# Altium schematic sheet sd card.SchDoc("sd card")
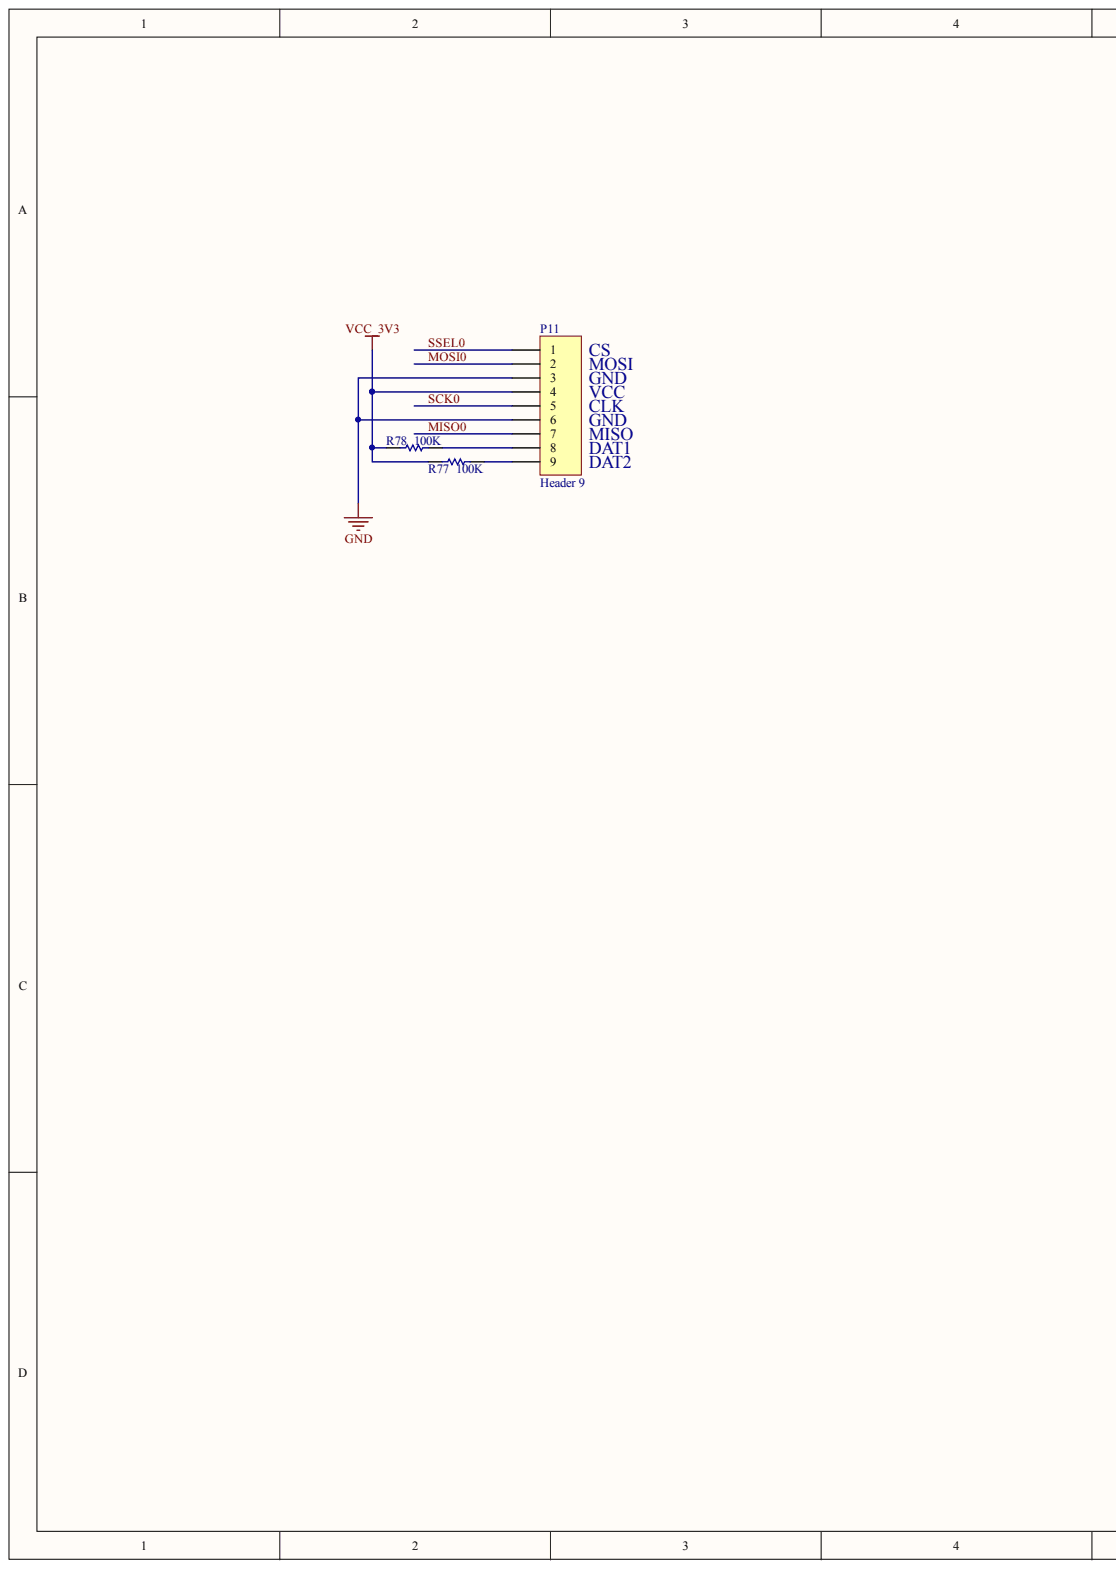
[diagram p0: sd card.SchDoc, left]
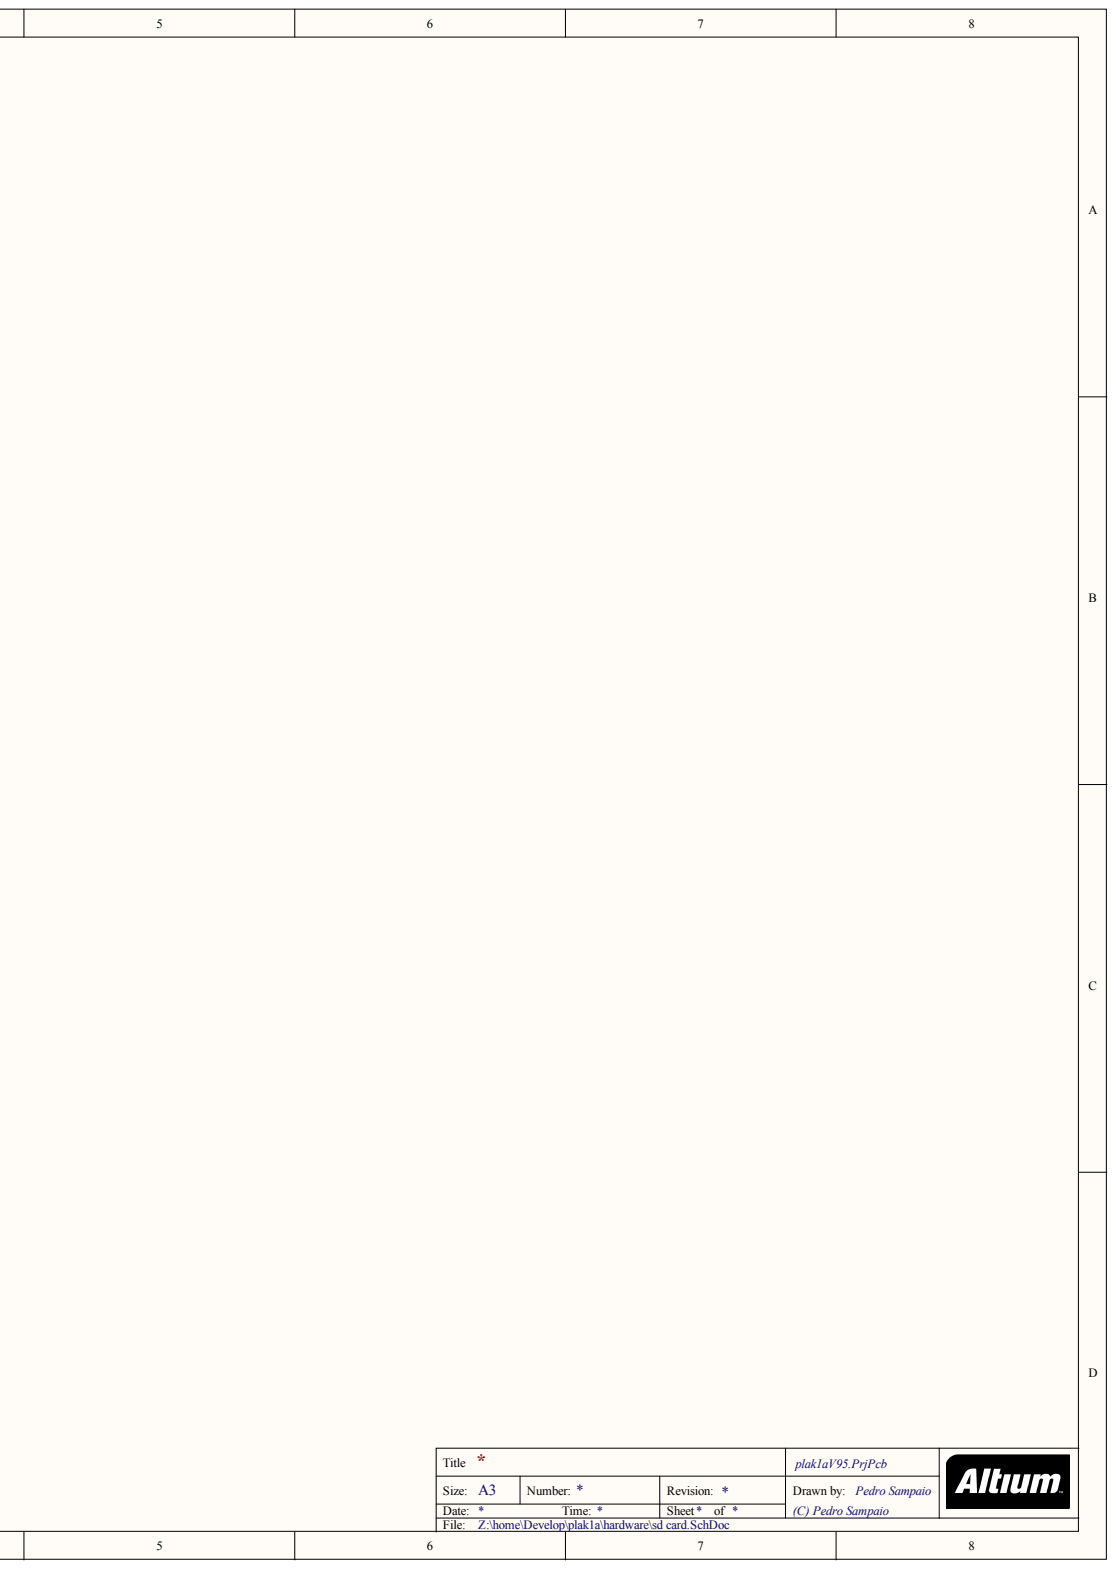
[diagram p1: sd card.SchDoc, right]

## Parts
P11  Header 9  [MC/SM2.5-4H9]
R77  value 100K  [3216[1206]]  (hidden comment: Res Semi)
R78  value 100K  [3216[1206]]  (hidden comment: Res Semi)

## Nets
GND: P11.3 P11.6
VCC_3V3: P11.4 R77.2 R78.2
MISO0: P11.7
MOSI0: P11.2
SCK0: P11.5
SSEL0: P11.1
NetP11_8: P11.8 R78.1
NetP11_9: P11.9 R77.1

## Library data of the parts
P11 = Header 9: Header, 9-Pin; Component Kind: Standard; LatestRevisionDate: 17-Jul-2002; LatestRevisionNote: Re-released for DXP Platform.; Library Name: Miscellaneous Connectors.IntLib; Signal Integrity: Connector; Publisher: Altium Limited
R77 R78 = Res Semi: Semiconductor Resistor; Component Kind: Standard; Farnell: 9240764; LatestRevisionDate: 17-Jul-2002; LatestRevisionNote: Re-released for DXP Platform.; Library Name: Miscellaneous Devices.IntLib; PackageReference: AXIAL-0.5; Published: 8-Jun-2000; Signal Integrity: Res Semi; Simulation: RES; Publisher: Altium Limited
